# Altium schematic sheet ICY40_A02_Top.SchDoc
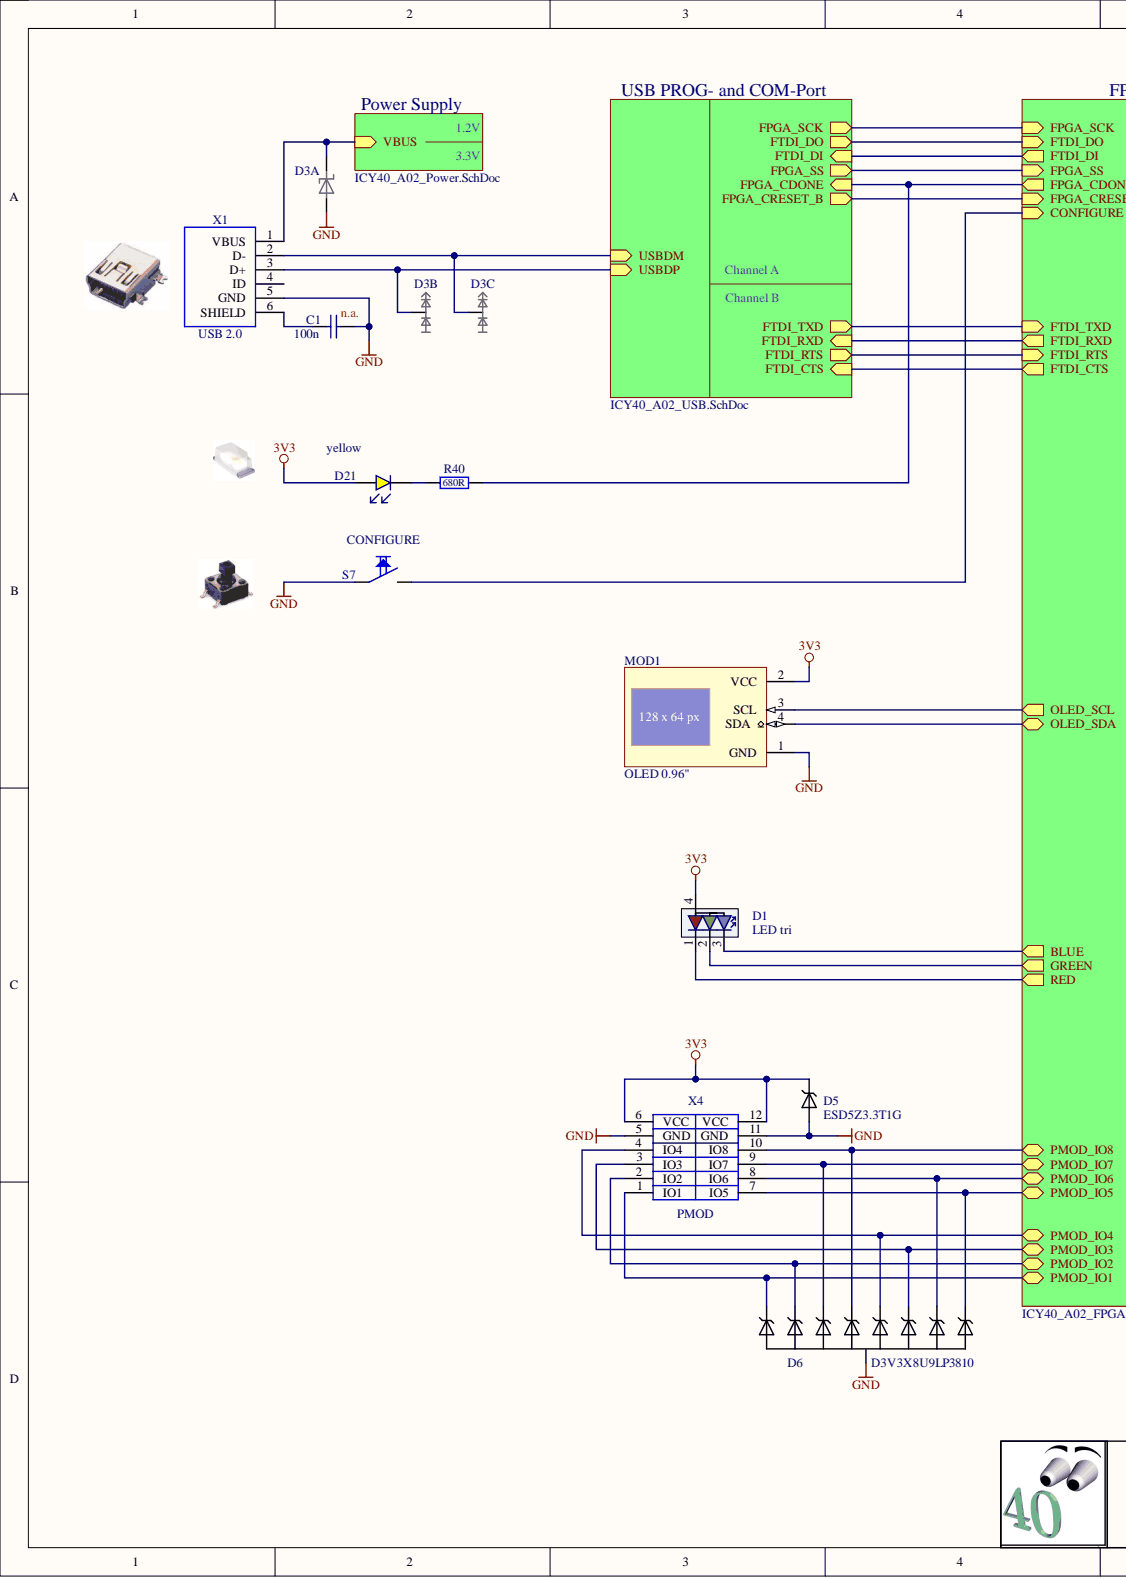
[diagram p0: ICY40_A02_Top.SchDoc, left]
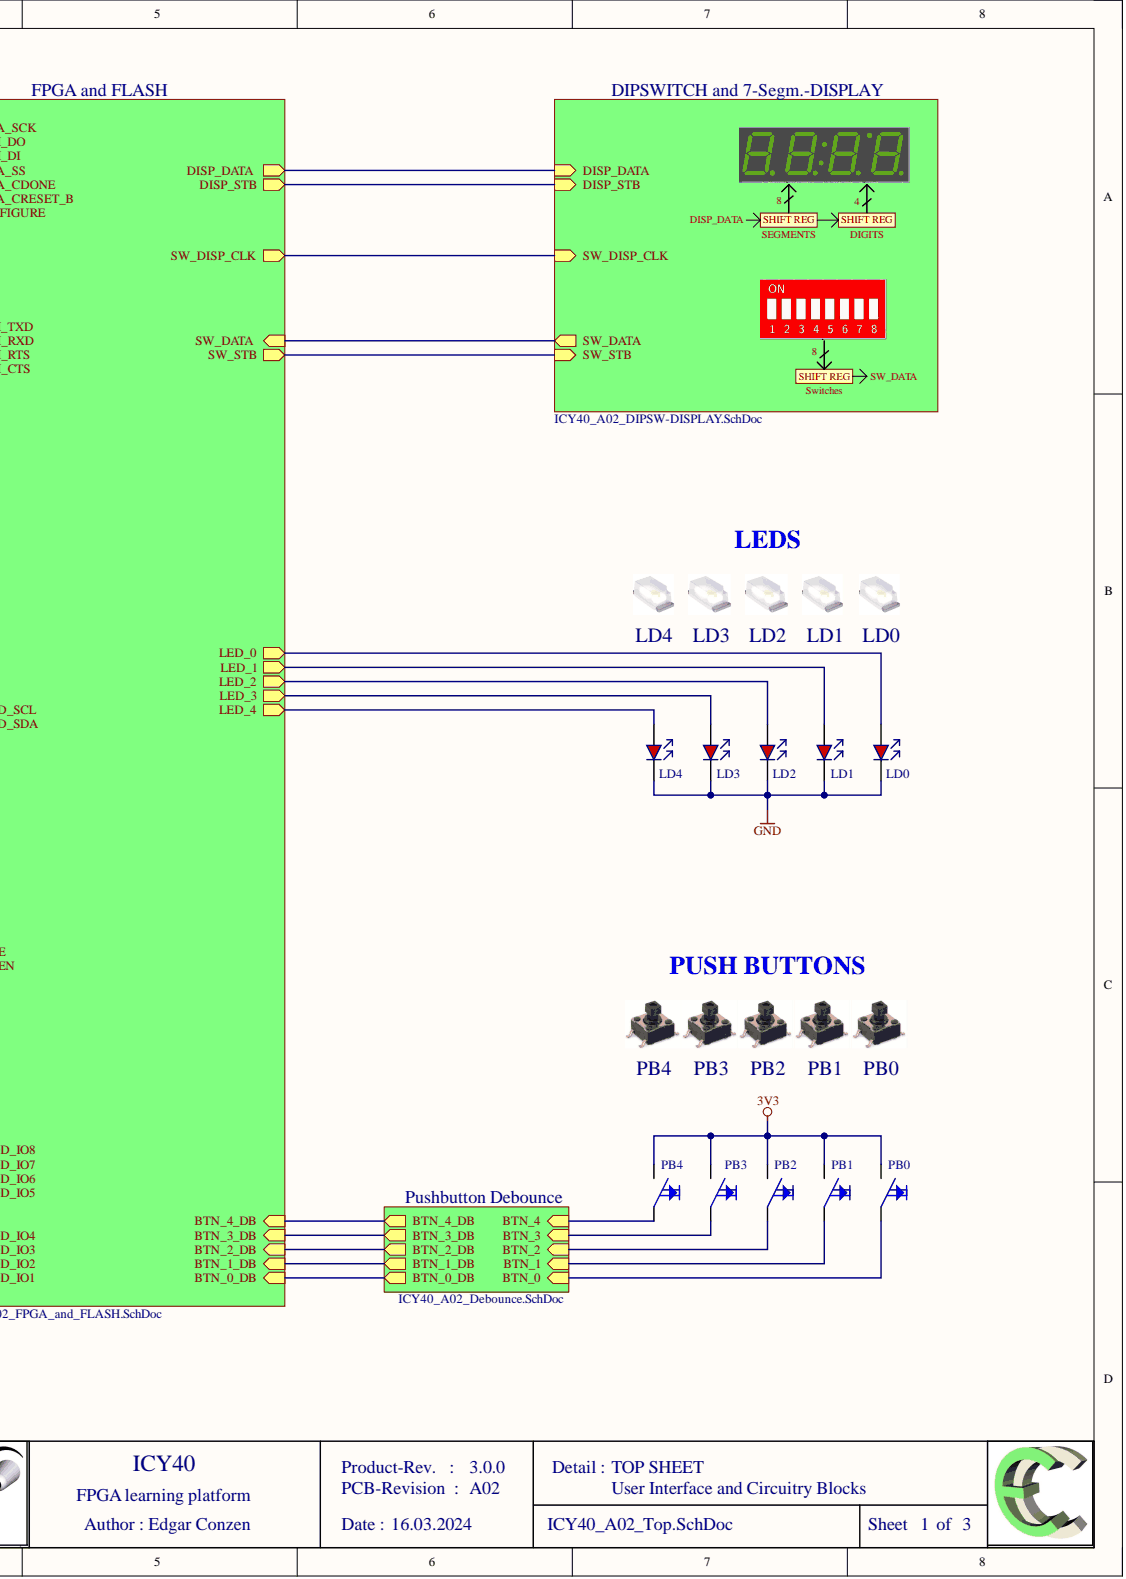
[diagram p1: ICY40_A02_Top.SchDoc, right]

## Parts
C1  100n  ESR: n.a.  [C_SMD_0603]
D1  LED tri  [0606_LEDtri_Lite-On]
D3  UDT14A05L03  [SOT-143]  (value not drawn)  (parts A,B,C)
D5  ESD5Z3.3T1G  [SOD-523]
D6  D3V3X8U9LP3810  [U-DFN3810-9_B]
D21  yellow  [LED_YE_0603_Osram_Smart]
LD0  red  [LED_RD_0603_Osram_Smart]  (value not drawn)
LD1  red  [LED_RD_0603_Osram_Smart]  (value not drawn)
LD2  red  [LED_RD_0603_Osram_Smart]  (value not drawn)
LD3  red  [LED_RD_0603_Osram_Smart]  (value not drawn)
LD4  red  [LED_RD_0603_Osram_Smart]  (value not drawn)
MOD1  OLED 0.96"  [SSD1306]
PB0  PB  DISPVAL: PB0  [PB_6.2x6.2mm_H7.3mm_ELTSM-644K]
PB1  PB  DISPVAL: PB1  [PB_6.2x6.2mm_H7.3mm_ELTSM-644K]
PB2  PB  DISPVAL: PB2  [PB_6.2x6.2mm_H7.3mm_ELTSM-644K]
PB3  PB  DISPVAL: PB3  [PB_6.2x6.2mm_H7.3mm_ELTSM-644K]
PB4  PB  DISPVAL: PB4  [PB_6.2x6.2mm_H7.3mm_ELTSM-644K]
R40  680R  [R_SMD_0603]
S7  DISPVAL: CONFIGURE  [PB_6.2x6.2mm_H7.3mm_ELTSM-644K]  (hidden comment: PB)
X1  USB 2.0  [USB-B-M5-SMD-Assmann]
X4  PMOD  [PMOD-12PIN-HOST]

## Nets
3V3: D1.4 D5.1 D21.1 MOD1.2(VCC) PB0.1 PB1.1 PB2.1 PB3.1 PB4.1 X4.6(VCC) X4.12(VCC)
GND: C1.2 D3.1 D5.2 D6.3 LD0.2 LD1.2 LD2.2 LD3.2 LD4.2 MOD1.1(GND) S7.2 X1.5(GND) X4.5(GND) X4.11(GND)
NetC1_1: C1.1 X1.6(SHIELD)
NetD1_1: D1.1
NetD1_2: D1.2
NetD1_3: D1.3
NetD3_2: D3.2 X1.3(D+)
NetD3_3: D3.3 X1.2(D-)
NetD3_4: D3.4 X1.1(VBUS)
NetD6_1: D6.1 X4.1(IO1)
NetD6_2: D6.2 X4.2(IO2)
NetD6_4: D6.4 X4.9(IO7)
NetD6_5: D6.5 X4.10(IO8)
NetD6_6: D6.6 X4.4(IO4)
NetD6_7: D6.7 X4.3(IO3)
NetD6_8: D6.8 X4.8(IO6)
NetD6_9: D6.9 X4.7(IO5)
NetD21_2: D21.2 R40.2
NetLD0_1: LD0.1
NetLD1_1: LD1.1
NetLD2_1: LD2.1
NetLD3_1: LD3.1
NetLD4_1: LD4.1
NetMOD1_3: MOD1.3(SCL)
NetMOD1_4: MOD1.4(SDA)
NetPB0_2: PB0.2
NetPB1_2: PB1.2
NetPB2_2: PB2.2
NetPB3_2: PB3.2
NetPB4_2: PB4.2
NetR40_1: R40.1
NetS7_1: S7.1
NetX1_4: X1.4(ID)
BTN_0_DB:
BTN_1_DB:
BTN_2_DB:
BTN_3_DB:
BTN_4_DB:
DISP_DATA:
DISP_STB:
FPGA_CRESET_B:
FPGA_SCK:
FPGA_SS:
FTDI_CTS:
FTDI_DI:
FTDI_DO:
FTDI_RTS:
FTDI_RXD:
FTDI_TXD:
SW_DATA:
SW_DISP_CLK:
SW_STB:

## Library data of the parts
C1 = C-CER_0603_100n_X7R_50V_10%: Non-Polarized Capacitor; ASSY_ALT: ---; ASSY_STEP: SMD1; ASSY_TYPE: SMD; COMPLIANCE: RoHS; Database Table Name: C-CER; LIB_STATE: Preliminary; MAT: X7R; MFG_NAME: various…; NB: B; TCC: 15%; TEMP_STORE: -55°C to +85°C; TOL: 10%; TYPE: C; VALUE: 0,1; VOLTAGE: 50V; ASSY_NOTE: ---; CASE: 0603; Component Kind: Standard; ComponentLink1Description: Datasheet; ComponentLink3Description: Manufacturer Link; D-ID: C-CER_0603_100n_X7R_50V_10%; DISPVAL: 100n; Library Name: C.DbLib; MFG_PARTNR: various…; MSL: 1; NOTES: ---; TEMP_USE: -55°C to +85°C; USAGE: ---
D1 = LED_0606_tri_Lite-On_LTST-C19HE1WT: LED 0606 TriColor CA Lite-On LTST-C19HE1WT; IM_PARTNR: 00005440; ASSY_NOTE: ---; ASSY_TYPE: SMD; Component Kind: Standard; ComponentLink1Description: Datasheet; ComponentLink1URL: http://svn.ib/altium/ds/_LED/LITEON_LTST-C19HE1WT_TriColor.pdf; D-ID: LED_0606_tri_Lite-On_LTST-C19HE1WT; Library Name: Optical.SchLib; MFG_NAME: Lite-On; MFG_PARTNR: LTST-C19HE1WT; Value: LED tri
D3 = UDT14A05L03: USB 2.0 power and data line protection; ASSY_TYPE: SMD; MFG_NAME: Yageo; Value: ESD; Component Kind: Standard; D-ID: UDT14A05L03; MFG_PARTNR: UDT14A05L03; Library Name: Icy40.SchLib
D5 = ESD5Z3.3T1G: ESD Protection Diode, working voltage 3,3V; ASSY_TYPE: SMD; MFG_NAME: Onsemi; Component Kind: Standard; ComponentLink1Description: Datasheet; D-ID: ESD5Z3.3T1G; MFG_PARTNR: ESD5Z3.3T1G; Library Name: Icy40.SchLib
D6 = D3V3X8U9LP3810: 8-fold ESD Protection Diode Array working voltage 3,3V; ASSY_TYPE: SMD; MFG_NAME: DiodesInc; Component Kind: Standard; ComponentLink1Description: Datasheet; D-ID: D3V3X8U9LP3810; MFG_PARTNR: D3V3X8U9LP3810; Library Name: Icy40.SchLib
D21 = LED_0603_ye_LYL29K: LED 0603 yellow; IM_PARTNR: 00003328; MFG_PARTNR: LY L29K; ASSY_TYPE: SMD; D-ID: LED_0603_ye_LGL29K; MFG_NAME: OSRAM; Value: LED yellow; Component Kind: Standard; ComponentLink1Description: Datasheet; ComponentLink1URL: http://svn.ib/altium/ds/_LED/OSRAM_SmartLED-0603_LxL29K_r-o-y_2004-12.pdf; Library Name: Optical.SchLib
LD0-LD4 = LED_0603_rd_LSL29K: LED 0603 Red; IM_PARTNR: 00003327; MFG_PARTNR: LS L29K; ASSY_TYPE: SMD; MFG_NAME: OSRAM; Value: LED red; Component Kind: Standard; ComponentLink1Description: Datasheet; ComponentLink1URL: http://svn.ib/altium/ds/_LED/OSRAM_SmartLED-0603_LxL29K_r-o-y_2004-12.pdf; D-ID: LED_0603_rd_LSL29K; Library Name: Optical.SchLib
MOD1 = SSD1306: OLED Module, 0.96", 128x64 pixel, I2C; Component Kind: Standard; D-ID: SSD1306; Library Name: Icy40.SchLib
PB0-PB4 S7 = PB_6.2x6.2mm_H7.3mm_ELTSM-644K: Push Button SMD 6.2x6.2mm, H=7.3mm; Manufacturer: Salecom; Component Kind: Standard; D-ID: PB_6.2x6.2mm_H7.3mm_ELTSM-644K; Library Name: Icy40.SchLib
R40 = R_0603_680R_1%_TCR100_0.1W: Resistor; IM_PARTNR: 00001913; ASSY_ALT: ---; ASSY_STEP: SMD1; ASSY_TYPE: SMD; COMPLIANCE: ROHS; Database Table Name: R; MFG_NAME: various…; NB: B; POWER: 0.1W; TCR: 100; TEMP_STORE: n/A; TEMP_USE: -55° .. +155°C; TOL: 1%; VALUE: 680; VOLTAGE: 75V; ASSY_NOTE: ---; CASE: 0603; Component Kind: Standard; ComponentLink1Description: Datasheet; ComponentLink2Description: Solder Profile; ComponentLink3Description: Manufacturer Link; D-ID: R_0603_680R_1%_TCR100_0.1W; DISPVAL: 680R; Library Name: R.DbLib; LIFECYCLE: Active; MFG_PARTNR: various…; MSL: 1; TYPE: R
X1 = USB-B-M5_SMD_ASSMANN: USB-B-Mini 5pin SMD Connector; Component Kind: Standard; D-ID: USB-B-M5_SMD_ASSMANN; DISPVAL: USB 2.0; Manufacturer: Assmann; Library Name: Icy40.SchLib
X4 = PMOD-12PIN-HOST: PMOD Host Connector (female) 12 pin version; Manufacturer: Diverse; DISPVAL: #Function#; Component Kind: Standard; D-ID: PMOD-12PIN-HOST; Library Name: Icy40.SchLib
